# Altium schematic sheet ACC.SchDoc(ACC)
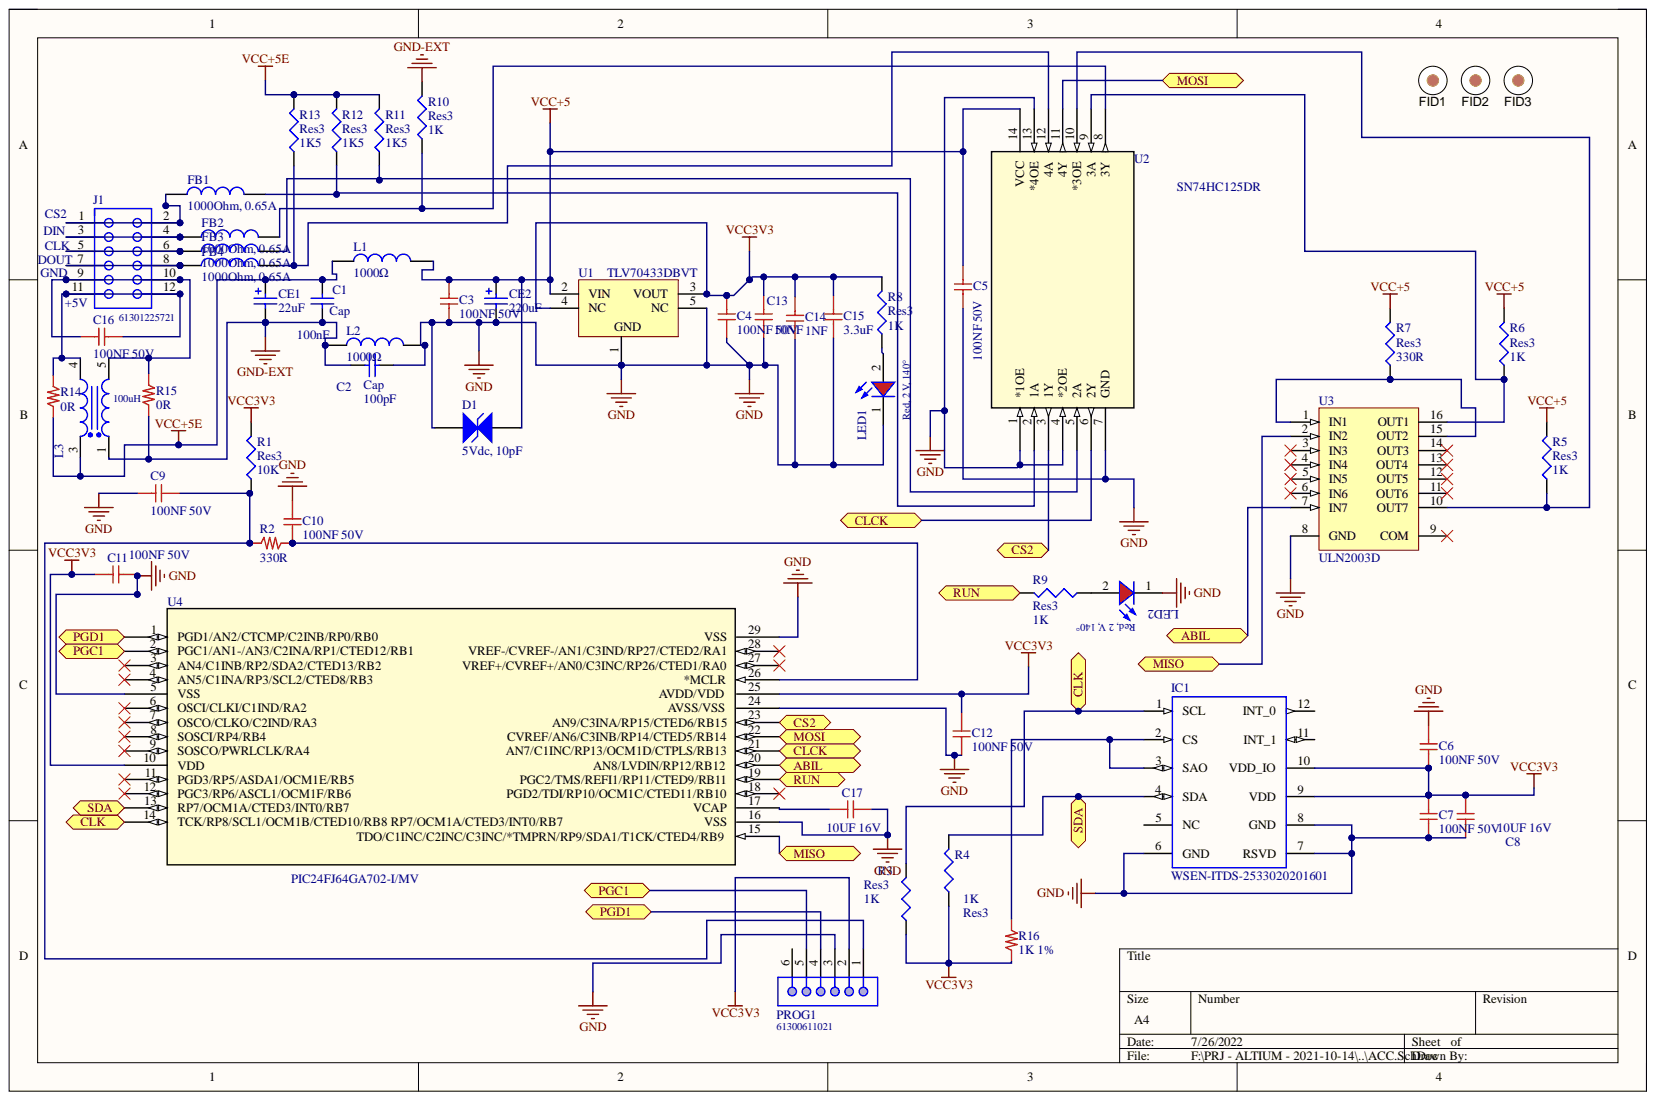
[diagram p0: ACC.SchDoc]

## Parts
C1  Cap  value 100nF  [1608[0603]]
C2  Cap  value 100pF  [1608[0603]]
C3  100NF 50V  [0603_c]
C4  100NF 50V  [0603_c]
C5  100NF 50V  [0603_c]
C6  100NF 50V  [0603_c]
C7  100NF 50V  [0603_c]
C8  10UF 16V  [0805_C]
C9  100NF 50V  [0603_c]
C10  100NF 50V  [0603_c]
C11  100NF 50V  [0603_c]
C12  100NF 50V  [0603_c]
C13  10NF  [0603_c]
C14  1NF  [0603_c]
C15  3.3uF  [0603_c]
C16  100NF 50V  [0603_c]
C17  10UF 16V  [0805_C]
CE1  value 22uF  [WCAP-ASLL_4x5.5]  (hidden comment: 865060140001)
CE2  value 220uF  [WCAP-ASLL_6.3x7.7]  (hidden comment: 865060245006)
D1  5Vdc, 10pF  Capacitance: 10pF  [WE-VE_0603]
FB1  1000Ohm, 0.65A  Rated Current: 0.65A  [WE-CBF_0603_Wide Band]
FB2  1000Ohm, 0.65A  Rated Current: 0.65A  [WE-CBF_0603_Wide Band]
FB3  1000Ohm, 0.65A  Rated Current: 0.65A  [WE-CBF_0603_Wide Band]
FB4  1000Ohm, 0.65A  Rated Current: 0.65A  [WE-CBF_0603_Wide Band]
FID1  Fiducial  [Fiducial10-20]  (value not drawn)
FID2  Fiducial  [Fiducial10-20]  (value not drawn)
FID3  Fiducial  [Fiducial10-20]  (value not drawn)
IC1  WSEN-ITDS-2533020201601  [WSEN-ITDS_2533020201601]
J1  61301225721  [61301225721]
L1  1000Ω  [WE-CBF_0805_High Current]
L2  1000Ω  [WE-CBF_0805_High Current]
L3  100uH  [WE-SL1]
LED1  Red, 2 V, 140°  Viewing Angle: 140°  [DIODO-0805]
LED2  Red, 2 V, 140°  Viewing Angle: 140°  [DIODO-0805]
PROG1  61300611021  [61300611021]
R1  Res3  value 10K  [J1-0603]
R2  330R  [0603_R]
R3  Res3  value 1K  [J1-0603]
R4  Res3  value 1K  [J1-0603]
R5  Res3  value 1K  [J1-0603]
R6  Res3  value 1K  [J1-0603]
R7  Res3  value 330R  [J1-0603]
R8  Res3  value 1K  [J1-0603]
R9  Res3  value 1K  [J1-0603]
R10  Res3  value 1K  [J1-0603]
R11  Res3  value 1K5  [J1-0603]
R12  Res3  value 1K5  [J1-0603]
R13  Res3  value 1K5  [J1-0603]
R14  0R  [1206_R]
R15  0R  [0805_R]
R16  1K 1%  [0603_R]
U1  TLV70433DBVT  [SOT95P245X110-5N]
U2  SN74HC125DR  [D14-M]
U3  ULN2003D  [SO16_N]
U4  PIC24FJ64GA702-I/MV  [UQFN28_MV_MCH-M]  (value not drawn)

## Ports
ABIL, CLCK, CLK, CS2, MISO, MOSI, PGC1, PGD1, RUN, SDA

## Nets
GND: C2.2 C3.2 C4.2 C5.2 C6.1 C7.2 C8.2 C9.1 C10.2 C11.1 C12.1 C13.2 C14.2 C15.2 C17.2 CE2.2 D1.1 IC1.6(GND) IC1.7(RSVD) IC1.8(GND) L2.2 LED1.1 LED2.1 PROG1.3 U1.1 U1.5(NC) U2.1(*1OE) U2.4(*2OE) U2.7(GND) U2.13(*4OE) U3.8(GND) U4.5(VSS) U4.16(VSS) U4.24(AVSS/VSS) U4.29(VSS)
GND-EXT: C1.1 C2.1 CE1.2 L2.1 L3.1 R10.2 R15.2
VCC3V3: C4.1 C6.2 C7.1 C8.1 C11.2 C12.2 C13.1 C14.1 C15.1 IC1.9(VDD) IC1.10(VDD_IO) PROG1.2 R1.2 R3.1 R4.1 R8.2 R16.2 U1.3(VOUT) U1.4(NC) U4.10(VDD) U4.25(AVDD/VDD)
VCC+5: C3.1 C5.1 CE2.1 D1.2 L1.2 R5.2 R6.2 R7.2 U1.2(VIN) U2.14(VCC)
VCC+5E: C1.2 CE1.1 L1.1 L3.3 R11.2 R12.2 R13.2 R14.1
ABIL: U3.7(IN7) U4.20(AN8/LVDIN/RP12/RB12) ; port ABIL
CLCK: U2.6(2Y) U4.21(AN7/C1INC/RP13/OCM1D/CTPLS/RB13) ; port CLCK
CLK: IC1.1(SCL) R3.2 U4.14 ; port CLK
CS2: U2.3(1Y) U4.23(AN9/C3INA/RP15/CTED6/RB15) ; port CS2
MISO: U3.2(IN2) U4.15(TDO/C1INC/C2INC/C3INC/*TMPRN/RP9/SDA1/T1CK/CTED4/RB9) ; port MISO
MOSI: U2.11(4Y) U4.22(CVREF/AN6/C3INB/RP14/CTED5/RB14) ; port MOSI
PGC1: PROG1.5 U4.2(PGC1/AN1-/AN3/C2INA/RP1/CTED12/RB1) ; port PGC1
PGD1: PROG1.4 U4.1(PGD1/AN2/CTCMP/C2INB/RP0/RB0) ; port PGD1
RUN: R9.2 U4.19(PGC2/TMS/REFI1/RP11/CTED9/RB11) ; port RUN
SDA: IC1.4(SDA) R4.2 U4.13(RP7/OCM1A/CTED3/INT0/RB7) ; port SDA
NetC9_2: C9.2 PROG1.1 R1.1 R2.1
NetC10_1: C10.1 R2.2 U4.26(*MCLR)
NetC16_1: C16.1 J1.9 J1.10 L3.5 R15.1
NetC16_2: C16.2 J1.11 J1.12 L3.4 R14.2
NetC17_1: C17.1 U4.17(VCAP)
NetFB1_1: FB1.1 J1.1 J1.2
NetFB1_2: FB1.2 R12.1 U2.2(1A)
NetFB2_1: FB2.1 J1.3 J1.4
NetFB2_2: FB2.2 R10.1 U2.8(3Y)
NetFB3_1: FB3.1 J1.5 J1.6
NetFB3_2: FB3.2 R11.1 U2.5(2A)
NetFB4_1: FB4.1 J1.7 J1.8
NetFB4_2: FB4.2 R13.1 U2.12(4A)
NetIC1_2: IC1.2(CS) IC1.3(SAO) R16.1
NetLED1_2: LED1.2 R8.1
NetLED2_2: LED2.2 R9.1
NetR5_1: R5.1 U2.10(*3OE) U3.10(OUT7)
NetR6_1: R6.1 U2.9(3A) U3.16(OUT1)
NetR7_1: R7.1 U3.1(IN1) U3.15(OUT2)

Not connected: IC1 5(NC) 11(INT_1) 12(INT_0); PROG1 6; U3 3(IN3) 4(IN4) 5(IN5) 6(IN6) 9(COM) 11(OUT6) 12(OUT5) 13(OUT4) 14(OUT3); U4 3(AN4/C1INB/RP2/SDA2/CTED13/RB2) 4(AN5/C1INA/RP3/SCL2/CTED8/RB3) 6(OSCI/CLKI/C1IND/RA2) 7(OSCO/CLKO/C2IND/RA3) 8(SOSCI/RP4/RB4) 9(SOSCO/PWRLCLK/RA4) 11(PGD3/RP5/ASDA1/OCM1E/RB5) 12(PGC3/RP6/ASCL1/OCM1F/RB6) 18(PGD2/TDI/RP10/OCM1C/CTED11/RB10) 27(VREF+/CVREF+/AN0/C3INC/RP26/CTED1/RA0) 28(VREF-/CVREF-/AN1/C3IND/RP27/CTED2/RA1)

## Library data of the parts
C1 = Cap: Capacitor; Value: 100nF; Component Kind: Standard; Library Name: HSW.IntLib
C2 = Cap: Capacitor; Component Kind: Standard; Library Name: HSW.IntLib
C3-C7 C9-C12 C16 = 100NF 50V: Cap X7R 100nF 50V Flex Terminal; Cod. Interno: COCS50665050104; Cod. Produttore: CL10B104KB8SFNC; Produttore: Samsung; Cod. DigiKey: 1276-1936-2-ND; Component Kind: Standard; Library Name: CapSMD0603.SchLib
C8 C17 = 10UF 16V: Cap X5R 10UF 16V; Cod. Interno: COCS517061600106; Cod. Produttore: C2012X6S1C106K125AC; Produttore: TDK; Cod. DigiKey: 445-7611-2-ND; Component Kind: Standard; Library Name: CapSMD0805.SchLib; Temperature Range: Da -55°C a +105°C
C13 = 10NF 50V: Cap X7R 10nF 50V; Cod. Interno: COCS 556655000103; Cod. Produttore: CL05B103KB5NNNC; Produttore: Samsung; Cod. DigiKey: 1276-1028-2-ND; Component Kind: Standard; Library Name: CapSMD0603.SchLib
C14 = 1NF 50V: Cap X7R 1nF 50V; Cod. Interno: COCS 50665050102; Component Kind: Standard; Library Name: CapSMD0603.SchLib
C15 = 3.3uF 16V: 3.3µF, 16V, ±10%, X5R 0603; Cod. Interno: COCS??; Cod. Produttore: C1608X5R1C335K080AC; Produttore: TDK; Component Kind: Standard; Library Name: CapSMD0603.SchLib
CE1 = 865060140001: WCAP-ASLL Aluminum Electrolytic Capacitors, size Ф4x5.5mm, 6.3V DC, 22uF; Rated Voltage: 6.3V DC; Component Kind: Standard; ComponentLink1Description: Manufacturer; ComponentLink1URL: http://www.we-online.de; ComponentLink2Description: Datasheet; ComponentLink2URL: http://katalog.we-online.de/pbs/datasheet/865060140001.pdf; Library Name: ALTIUM-WCAP-ASLL-rev17a-4.IntLib; Life Cycle: 2000h; Operating Temperature: -55 °C up to +105 °C; Part Number: 865060140001; Published Date: June 2017; Size: Ф4x5.5mm; Publisher: Wurth Elektronik
CE2 = 865060245006: WCAP-ASLL Aluminum Electrolytic Capacitors, size Ф6.3x7.7mm, 10V DC, 220uF; Rated Voltage: 10V DC; Component Kind: Standard; ComponentLink1Description: Manufacturer; ComponentLink1URL: http://www.we-online.de; ComponentLink2Description: Datasheet; ComponentLink2URL: http://katalog.we-online.de/pbs/datasheet/865060245006.pdf; Library Name: WCAP-ASLL.SchLib; Life Cycle: 2000h; Operating Temperature: -55 °C up to +105 °C; Part Number: 865060245006; Published Date: June 2017; Size: Ф6.3x7.7mm; Publisher: Wurth Elektronik
D1 = 82356050100: WE-VE ESD Suppressor, size 0603, 5Vdc, 10pF; Clamp Voltage: 60V; ESD Voltage air discharge: 15kV; Leakage Current: 1uA; Component Kind: Standard; ComponentLink1Description: Manufacturer; ComponentLink1URL: http://www.we-online.de; ComponentLink2Description: Datasheet; ComponentLink2URL: https://katalog.we-online.com/pbs/datasheet/82356050100.pdf; Library Name: WE-VE_0603.SchLib; Mounting Technology: SMT; Operating Temperature: -40 to +85degC; Packaging: Tape and Reel; Part Number: 82356050100; Published Date: June 2019; Size: 0603; Working Voltage: 5Vdc; Publisher: Wurth Elektronik
FB1-FB4 = 74279266: WE-CBF SMT EMI Suppression Ferrite Bead, size 0603, 1000Ohm, 0.65A; DC Resistance max.: 0.6Ohm; Impedance max.: 1350Ohm; Type: Wide Band; Component Kind: Standard; ComponentLink1Description: Manufacturer; ComponentLink1URL: http://www.we-online.de; ComponentLink2Description: Datasheet; ComponentLink2URL: https://katalog.we-online.com/pbs/datasheet/74279266.pdf; Impedance@100 MHz: 1000Ohm; Library Name: WE-CBF_0603.SchLib; Mounting Technology: SMT; Operating Temperature: -55 to +125degC; Packaging: Tape and Reel; Part Number: 74279266; Published Date: March 2019; Size: 0603; Publisher: Wurth Elektronik
FID1-FID3 = Fiducial: Fiducial mark.  There is nothing to buy or mount.; SymbolCreator: codlsc; ClassName: FiducialMark; Component Kind: Standard; CompType: Fiducial; LatestRevisionDate: 2015-10-18; LatestRevisionNote: Added Alternate PartNumber and Alternate Manufacturer parameters to appropriate components.; Manufacturer: N/A; MountType: N/A; PackageReference: N/A; PartNumber: N/A; SymbolVersion: 2009-11-4; Library Name: HSW.IntLib; Publisher: Texas Instruments
IC1 = WSEN-ITDS_2533020201601: Manufacturer: Würth Elektronik; Component Kind: Standard; Library Name: WE_WSEN-REV4.1.SchLib; NR: 2533020201601
J1 = 61301225721: WR-PHD Socket Header, THT, pitch 2.54mm, Dual Row, Vertical, 12pin; Contact Resistance: 20mOhm; Gender: Female; Pin Number: 12; Rated Current: 3A; Withstanding Voltage: 500VAC; Working Voltage: 250VAC; Component Kind: Standard; ComponentLink1Description: Manufacturer; ComponentLink1URL: http://www.we-online.de; ComponentLink2Description: Datasheet; ComponentLink2URL: https://katalog.we-online.de/em/datasheet/6130xx25721.pdf; Library Name: WR-PHD_Socket Header_2.54mm.SchLib; Mounting Technology: THT; Operating Temperature: -40 to +125degC; Orientation: Vertical; Packaging: Tube; Part Number: 61301225721; Pitch: 2.54mm; Published Date: June 2019; Row Number: Dual; Publisher: Wurth Elektronik
L1 L2 = WE-CBF, 742792096: WE-CBF SMD EMI Suppression Ferrite Bead, 0805, 1000Ω; Type: High Current; Component Kind: Standard; ComponentLink1Description: Manufacturer; ComponentLink1URL: http://www.we-online.de; ComponentLink2Description: Datasheet; ComponentLink2URL: http://katalog.we-online.de/pbs/datasheet/742792096.pdf; Library Name: WE-CBF_0805.SchLib; PartNumber: 742792096; PublishedDate: Aug 2016; Size: 0805; Value: 1000Ω; Publisher: Wurth Elektronik
L3 = 744212101: WE-SL1 SMT Common Mode Line Filter, 100uH, 300mA, 80V; DC Resistance max.: 0.22Ohm; Impedance max.: 500Ohm; Insulation Test Voltage: 250V (AC); Leakage Inductance: 530nH; Winding Style: sectional; Component Kind: Standard; ComponentLink1Description: Manufacturer; ComponentLink1URL: http://www.we-online.de; ComponentLink2Description: Datasheet; ComponentLink2URL: https://katalog.we-online.com/pbs/datasheet/744212101.pdf; Inductance: 100uH; Library Name: WE-SL1.SchLib; Mounting Technology: SMT; Operating Temperature: -40 to +105degC; Packaging: Tape and Reel; Part Number: 744212101; Published Date: March 2019; Rated Current: 300mA; Rated Voltage: 80V; Publisher: Wurth Elektronik
LED1 LED2 = DIODO-0805: WL-SMCW SMD Mono-color Chip LED Waterclear, size 0805, Red, 2 V, 140°; Part Number: 150080RS75000; Dominant Wavelength: 625 nm; Forward Voltage: 2 V; Luminous Intensity: 150 mcd; Peak Wavelength: 630 nm; Component Kind: Standard; ComponentLink1Description: Manufacturer; ComponentLink1URL: http://www.we-online.de; ComponentLink2Description: Datasheet; ComponentLink2URL: http://katalog.we-online.de/led/datasheet/150080RS75000.pdf; Emitting Color: Red; Mounting Technology: SMT; Operating Temperature: -40 to 85 degC; Published Date: May 2018; Size: 0805; Library Name: HSW.IntLib; Publisher: Wurth Elektronik
PROG1 = 61300611021: THT Angled Pin Header WR-PHD, Pitch 2.54 mm, Single Row, 6 pins; Contact Resistance: 20 mOhm; Gender: Male; Pin Number: 6; Pitch: 2.54 mm; Rated Current: 3 A; Withstanding Voltage: 500 VAC; Working Voltage: 250 VAC; Component Kind: Standard; ComponentLink1Description: Manufacturer; ComponentLink1URL: http://www.we-online.de; ComponentLink2Description: Datasheet; ComponentLink2URL: https://katalog.we-online.de/em/datasheet/6130xx11021.pdf; Library Name: Pin Header WR-PHD_2.54 mm.SchLib; Mounting Technology: THT; Operating Temperature: -40 to 105 degC; Orientation: Angled; Packaging: Bag; Part Number: 61300611021; Published Date: August 2018; Row Number: Single; Publisher: Wurth Elektronik
R1 R3-R13 = Res3: Resistor; Component Kind: Standard; LatestRevisionDate: 29-May-2009; LatestRevisionNote: IPC-7351 Footprint Added.; PackageDescription: Chip Resistor; PackageReference: J1-0603; Published: 8-Jun-2000; Signal Integrity: Res; Simulation: RESISTOR; Library Name: HSW.IntLib; Publisher: Altium Limited
R2 = 330R: Res Film Spesso 1/16W 330R 5%; Cod. Interno: RESS4166556B0331; Component Kind: Standard; Library Name: ResSMD0603.SchLib
R14 = OR: 0R 1206 1/4W; Case: 1206; Component Kind: Standard; Library Name: ResSMD1206.SchLib
R15 = 0R: Res Film Spesso 1/2W 0R; Cod. Interno: RESS4170555B0000; Potenza: 1/2W 5%; Component Kind: Standard; Library Name: ResSMD0805.SchLib
R16 = 1K 1%: Res Film Spesso 1/16W 1K 1%; Cod. Interno: RESS4166356B0102; Cod. Produttore: ??; Component Kind: Standard; Library Name: ResSMD0603.SchLib; Produttore: ??
U1 = TLV70433DBVT: 3-Terminal Positive Voltage Regulator; Code_IPC: SOIC127P600-8; Component Kind: Standard; ComponentLink1Description: Manufacturer Link; ComponentLink1URL: http://www.ti.com/; DatasheetVersion: Oct-1988; LatestRevisionDate: 13-Apr-2006; LatestRevisionNote: IPC-7351 Footprint Added.; PackageDescription: 8-Pin Small Outline Integrated Circuit 1.27 mm Pitch; PackageReference: D008; PackageVersion: Jan-1998; Published: 15-Apr-2002; Library Name: HSW.IntLib; Publisher: Altium Limited
U2 = SN74HC125DR: Imported; Built_By: EMA_UL_Team; C: Copyright (C) 2015 Accelerated Designs. All rights reserved; Vendor: Texas Instruments; Component Kind: Standard; Manufacturer_Part_Number: SN74HC125DR; RefDes: RefDes; Type: DEV; Value: Value; Library Name: HSW.IntLib
U3 = ULN2003D: Seven Darlington Array; Code_IPC: SOIC127P600-16; Component Kind: Standard; ComponentLink1Description: Manufacturer Link; ComponentLink1URL: http://www.st.com/; ComponentLink2Description: Datasheet; ComponentLink2URL: http://www.st.com/stonline/products/literature/ds/5279/uln2001.pdf; DatasheetVersion: Sep-1998; LatestRevisionDate: 13-Apr-2006; LatestRevisionNote: IPC-7351 Footprint Added.; PackageDescription: 16-Pin Small Outline Integrated Circuit 1.27 mm Pitch; PackageReference: SO16; PackageVersion: May-1998; Published: 8-Jun-2000; Library Name: HSW.IntLib; Publisher: Altium Limited
U4 = PIC24FJ64GA702-I/MV: 16-Bit General Purpose Microcontrollers with 256-Kbyte Flash and  16-Kbyte RAM in Low Pin Count Packages; Mfr_Name: Microchip; Component Kind: Standard; Digi-Key_Part_Number_1: PIC24FJ64GA702-I/MV-ND; Manufacturer_Part_Number: PIC24FJ64GA702-I/MV; RefDes: RefDes; Type: DEV; Copyright: Copyright (C) 2022 Ultra Librarian. All rights reserved.; Library Name: HSW.SchLib
